# Altium schematic sheet MCU.SchDoc(MCU)
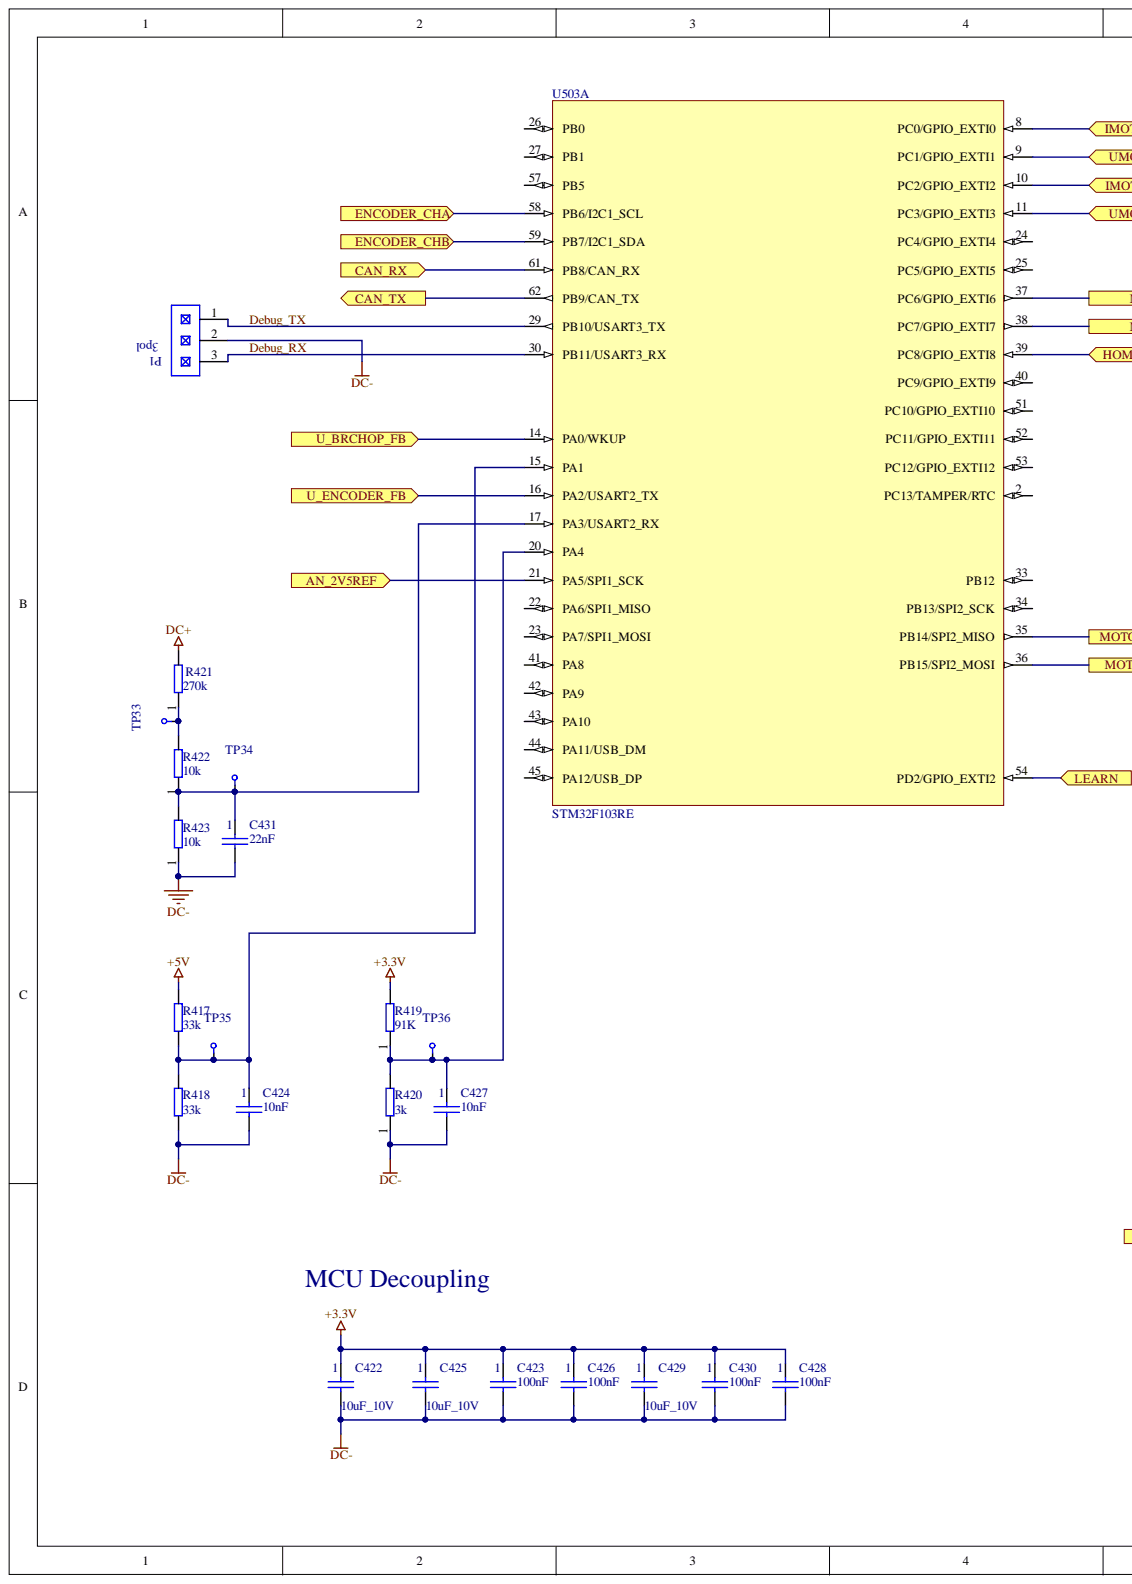
[diagram p0: MCU.SchDoc, left]
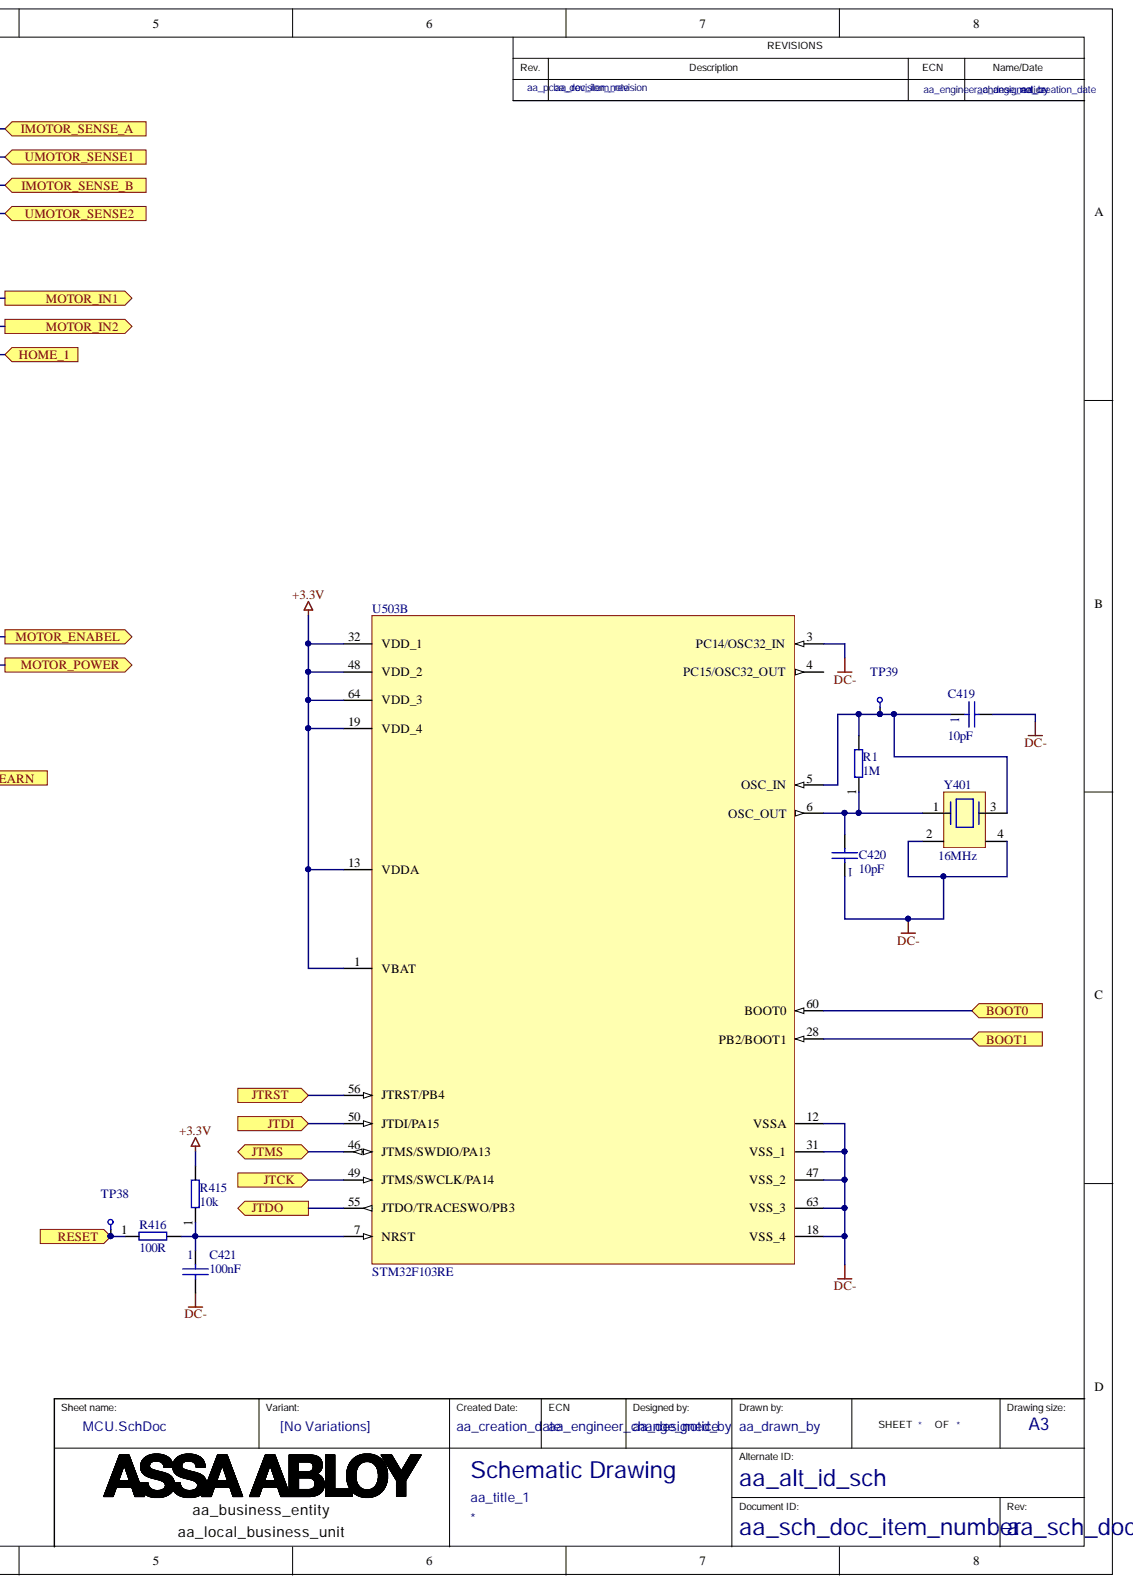
[diagram p1: MCU.SchDoc, right]

## Parts
C419  10pF  [CAPC1608X08N]
C420  10pF  [CAPC1608X08N]
C421  100nF  [CAPC1608X08N]
C422  10uF_10V  [0603C/H]
C423  100nF  [CAPC1608X08N]
C424  10nF  [CAPC1608X08N]
C425  10uF_10V  [0603C/H]
C426  100nF  [CAPC1608X08N]
C427  10nF  [CAPC1608X08N]
C428  100nF  [CAPC1608X08N]
C429  10uF_10V  [0603C/H]
C430  100nF  [CAPC1608X08N]
C431  22nF  [CAPC1608X08N]
P1  3pol  [HDRV3W64P254_3X1_762X248H838]
R1  1M  [RESC1608X55N]
R415  10k  [RESC1608X05N]
R416  100R  [RESC1608X05N]
R417  33k  [RESC1608X05N]
R418  33k  [RESC1608X05N]
R419  91K  [RESC1608X55N]
R420  3k  [RESC1608X05N]
R421  270k  [RESC1608X05N]
R422  10k  [RESC1608X05N]
R423  10k  [RESC1608X05N]
TP33  Testpoint SMD  [PCB_PARTS_TESTPOINT100_SMD]  (value not drawn)  (no BOM)
TP34  Testpoint SMD  [PCB_PARTS_TESTPOINT100_SMD]  (value not drawn)  (no BOM)
TP35  Testpoint SMD  [PCB_PARTS_TESTPOINT100_SMD]  (value not drawn)  (no BOM)
TP36  Testpoint SMD  [PCB_PARTS_TESTPOINT100_SMD]  (value not drawn)  (no BOM)
TP38  Testpoint SMD  [PCB_PARTS_TESTPOINT100_SMD]  (value not drawn)  (no BOM)
TP39  Testpoint SMD  [PCB_PARTS_TESTPOINT100_SMD]  (value not drawn)  (no BOM)
U503  STM32F103RE  [TSQFP50P1200X1200X160-64N]  (parts A,B)
Y401  16MHz  [XTAL_TSX-3225]

## Ports
AN_2V5REF, BOOT0, BOOT1, CAN_RX, CAN_TX, ENCODER_CHA, ENCODER_CHB, HOME_1, IMOTOR_SENSE_A, IMOTOR_SENSE_B, JTCK, JTDI, JTDO, JTMS, JTRST, LEARN, MOTOR_ENABEL, MOTOR_IN1, MOTOR_IN2, MOTOR_POWER, RESET, U_BRCHOP_FB, U_ENCODER_FB, UMOTOR_SENSE1, UMOTOR_SENSE2

## Nets
+3.3V: C422.1 C423.1 C425.1 C426.1 C428.1 C429.1 C430.1 R415.2 R419.2 U503.1(VBAT) U503.13(VDDA) U503.19(VDD_4) U503.32(VDD_1) U503.48(VDD_2) U503.64(VDD_3)
+5V: R417.2
DC+: R421.2
DC-: C419.2 C420.1 C421.2 C422.2 C423.2 C424.2 C425.2 C426.2 C427.2 C428.2 C429.2 C430.2 C431.2 P1.2 R418.1 R420.1 R423.1 U503.3(PC14/OSC32_IN) U503.12(VSSA) U503.18(VSS_4) U503.31(VSS_1) U503.47(VSS_2) U503.63(VSS_3) Y401.2 Y401.4
AN_2V5REF: U503.21(PA5/SPI1_SCK) ; port AN_2V5REF
BOOT0: U503.60(BOOT0) ; port BOOT0
BOOT1: U503.28(PB2/BOOT1) ; port BOOT1
CAN_RX: U503.61(PB8/CAN_RX) ; port CAN_RX
CAN_TX: U503.62(PB9/CAN_TX) ; port CAN_TX
Debug_RX: P1.3 U503.30(PB11/USART3_RX)
Debug_TX: P1.1 U503.29(PB10/USART3_TX)
ENCODER_CHA: U503.58(PB6/I2C1_SCL) ; port ENCODER_CHA
ENCODER_CHB: U503.59(PB7/I2C1_SDA) ; port ENCODER_CHB
HOME_1: U503.39(PC8/GPIO_EXTI8) ; port HOME_1
IMOTOR_SENSE_A: U503.8(PC0/GPIO_EXTI0) ; port IMOTOR_SENSE_A
IMOTOR_SENSE_B: U503.10(PC2/GPIO_EXTI2) ; port IMOTOR_SENSE_B
JTCK: U503.49(JTMS/SWCLK/PA14) ; port JTCK
JTDI: U503.50(JTDI/PA15) ; port JTDI
JTDO: U503.55(JTDO/TRACESWO/PB3) ; port JTDO
JTMS: U503.46(JTMS/SWDIO/PA13) ; port JTMS
JTRST: U503.56(JTRST/PB4) ; port JTRST
LEARN: U503.54(PD2/GPIO_EXTI2) ; port LEARN
MOTOR_ENABEL: U503.35(PB14/SPI2_MISO) ; port MOTOR_ENABEL
MOTOR_IN1: U503.37(PC6/GPIO_EXTI6) ; port MOTOR_IN1
MOTOR_IN2: U503.38(PC7/GPIO_EXTI7) ; port MOTOR_IN2
MOTOR_POWER: U503.36(PB15/SPI2_MOSI) ; port MOTOR_POWER
RESET: R416.1 TP38.1 ; port RESET
U_BRCHOP_FB: U503.14(PA0/WKUP) ; port U_BRCHOP_FB
U_ENCODER_FB: U503.16(PA2/USART2_TX) ; port U_ENCODER_FB
UMOTOR_SENSE1: U503.9(PC1/GPIO_EXTI1) ; port UMOTOR_SENSE1
UMOTOR_SENSE2: U503.11(PC3/GPIO_EXTI3) ; port UMOTOR_SENSE2
NetC419_1: C419.1 R1.2 TP39.1 U503.5(OSC_IN) Y401.3
NetC420_2: C420.2 R1.1 U503.6(OSC_OUT) Y401.1
NetC421_1: C421.1 R415.1 R416.2 U503.7(NRST)
NetC424_1: C424.1 R417.1 R418.2 TP35.1 U503.15(PA1)
NetC427_1: C427.1 R419.1 R420.2 TP36.1 U503.20(PA4)
NetC431_1: C431.1 R422.1 R423.2 TP34.1 U503.17(PA3/USART2_RX)
NetR421_1: R421.1 R422.2 TP33.1

Not connected: U503 2(PC13/TAMPER/RTC) 4(PC15/OSC32_OUT) 22(PA6/SPI1_MISO) 23(PA7/SPI1_MOSI) 24(PC4/GPIO_EXTI4) 25(PC5/GPIO_EXTI5) 26(PB0) 27(PB1) 33(PB12) 34(PB13/SPI2_SCK) 40(PC9/GPIO_EXTI9) 41(PA8) 42(PA9) 43(PA10) 44(PA11/USB_DM) 45(PA12/USB_DP) 51(PC10/GPIO_EXTI10) 52(PC11/GPIO_EXTI11) 53(PC12/GPIO_EXTI12) 57(PB5)

## Library data of the parts
C419 C420 = 1700530: Capacitor; APPROVED_MPN_01: C1608CH1H100D  RoHS; APPROVED_MANUFACTURER_01: TDK; PHYSICALPACKAGE: EIA0603; MOUNTEDTYPE: SMD; Database Table Name: Capacitors_Ceramic; VALUE: 10pF; TOLERANCE: -; PROTOTYPE_MPN_01: -; PROTOTYPE_MANUFACTURER_01: -; POWERRATING: -; PARTNUMBER: 1700530; PARTNAME: Capacitor; Library Name: AltiumLib_Database.DbLib; Component Kind: Standard; APPROVED_MPN_08: -; APPROVED_MPN_07: -; APPROVED_MPN_06: -; APPROVED_MPN_05: -; APPROVED_MPN_04: -; APPROVED_MPN_03: -; APPROVED_MPN_02: -; APPROVED_MANUFACTURER_08: -; APPROVED_MANUFACTURER_07: -; APPROVED_MANUFACTURER_06: -; APPROVED_MANUFACTURER_05: -; APPROVED_MANUFACTURER_04: -; APPROVED_MANUFACTURER_03: -; APPROVED_MANUFACTURER_02: -
C421 C423 C426 C428 C430 = 715219: Capacitor; APPROVED_MPN_05: 223878615649 ,X7R, 0603, 10%; APPROVED_MPN_04: CC0603KRX7R7BB104; APPROVED_MPN_03: 0603YC104KAT ,X7R,0603,10%; APPROVED_MPN_02: GRM188R71C104KA01; APPROVED_MPN_01: CL10B104KO8NNN; APPROVED_MANUFACTURER_05: Yageo Phy; APPROVED_MANUFACTURER_04: YAGEO; APPROVED_MANUFACTURER_03: AVX KYOCERA; APPROVED_MANUFACTURER_02: MURATA; APPROVED_MANUFACTURER_01: SAMSUNG; PHYSICALPACKAGE: EIA0603; MOUNTEDTYPE: SMD; Database Table Name: Capacitors_Ceramic; VALUE: 100nF; TOLERANCE: -; PROTOTYPE_MPN_01: -; PROTOTYPE_MANUFACTURER_01: -; POWERRATING: -; PARTNUMBER: 715219; PARTNAME: Capacitor; Library Name: AltiumLib_Database.DbLib; Component Kind: Standard; APPROVED_MPN_08: -; APPROVED_MPN_07: -; APPROVED_MPN_06: -; APPROVED_MANUFACTURER_08: -; APPROVED_MANUFACTURER_07: -; APPROVED_MANUFACTURER_06: -
C422 C425 C429 = Capacitor-NonPolarized: 0603; Library Name: Capacitor-NonPolarized.SchLib; Component Kind: Standard
C424 C427 = 1700542: Capacitor; APPROVED_MPN_01: C1608CH1E103J  RoHS; APPROVED_MANUFACTURER_01: TDK; PHYSICALPACKAGE: EIA0603; MOUNTEDTYPE: SMD; Database Table Name: Capacitors_Ceramic; VALUE: 10nF; TOLERANCE: -; PROTOTYPE_MPN_01: -; PROTOTYPE_MANUFACTURER_01: -; POWERRATING: -; PARTNUMBER: 1700542; PARTNAME: Capacitor; Library Name: AltiumLib_Database.DbLib; Component Kind: Standard; APPROVED_MPN_08: -; APPROVED_MPN_07: -; APPROVED_MPN_06: -; APPROVED_MPN_05: -; APPROVED_MPN_04: -; APPROVED_MPN_03: -; APPROVED_MPN_02: -; APPROVED_MANUFACTURER_08: -; APPROVED_MANUFACTURER_07: -; APPROVED_MANUFACTURER_06: -; APPROVED_MANUFACTURER_05: -; APPROVED_MANUFACTURER_04: -; APPROVED_MANUFACTURER_03: -; APPROVED_MANUFACTURER_02: -
C431 = 715500: Capacitor; APPROVED_MPN_04: 2238586_5641 (RoHS); APPROVED_MPN_03: GRM188R71H223KA01_ (RoHS); APPROVED_MPN_02: C0603C223K5RAC (RoHS); APPROVED_MPN_01: 06035C223KAT2A (RoHS); APPROVED_MANUFACTURER_04: Yageo Phycomp.; APPROVED_MANUFACTURER_03: MURATA; APPROVED_MANUFACTURER_02: KEMET; APPROVED_MANUFACTURER_01: AVX; PHYSICALPACKAGE: EIA0603; MOUNTEDTYPE: SMD; Database Table Name: Capacitors_Ceramic; VALUE: 22nF; TOLERANCE: -; PROTOTYPE_MPN_01: -; PROTOTYPE_MANUFACTURER_01: -; POWERRATING: -; PARTNUMBER: 715500; PARTNAME: Capacitor; Library Name: AltiumLib_Database.DbLib; Component Kind: Standard; APPROVED_MPN_08: -; APPROVED_MPN_07: -; APPROVED_MPN_06: -; APPROVED_MPN_05: -; APPROVED_MANUFACTURER_08: -; APPROVED_MANUFACTURER_07: -; APPROVED_MANUFACTURER_06: -; APPROVED_MANUFACTURER_05: -
P1 = 707279: 3pol connector; APPROVED_MPN_06: 1125-1103GOS11201; APPROVED_MPN_05: 4711955103490; APPROVED_MPN_04: 0-826646-3; APPROVED_MPN_03: 2211S-03G; APPROVED_MPN_02: 010 01 80 112 003; APPROVED_MPN_01: STL1-1080-GGT-003-U; APPROVED_MANUFACTURER_06: WCON; APPROVED_MANUFACTURER_05: Kontek-Comatel; APPROVED_MANUFACTURER_04: AMP; APPROVED_MANUFACTURER_03: Neltron; APPROVED_MANUFACTURER_02: Ratioplast; APPROVED_MANUFACTURER_01: MPE-GARRY; PHYSICALPACKAGE: 3pol 2.54mm; MOUNTEDTYPE: SMD; Database Table Name: CONNECTORS; VALUE: 3pol; TOLERANCE: -; PROTOTYPE_MPN_01: -; PROTOTYPE_MANUFACTURER_01: -; POWERRATING: -; PARTNUMBER: 707279; PARTNAME: 3pol; Library Name: AltiumLib_Database.DbLib; Component Kind: Standard; APPROVED_MPN_08: -; APPROVED_MPN_07: -; APPROVED_MANUFACTURER_08: -; APPROVED_MANUFACTURER_07: -
R1 = 714858: Resistor; APPROVED_MPN_05: MCT0603-50,1%,1M Lead Free (RoHS); APPROVED_MPN_04: MCR03-X-F-1M (RoHS); APPROVED_MPN_03: RK73H1JTTD1MF (RoHS); APPROVED_MPN_02: RC22H,2322704X19101; APPROVED_MPN_01: D11, 1M/1% ET_ E3 (RoHS); APPROVED_MANUFACTURER_05: BEYSCHLAG; APPROVED_MANUFACTURER_04: ROHM; APPROVED_MANUFACTURER_03: KOA; APPROVED_MANUFACTURER_02: Yageo; APPROVED_MANUFACTURER_01: Vishay OKAB ROE; TOLERANCE: 1%; POWERRATING: 0.063W; PHYSICALPACKAGE: EIA0603; MOUNTEDTYPE: SMD; Database Table Name: Resistors; VALUE: 1M; PROTOTYPE_MPN_01: -; PROTOTYPE_MANUFACTURER_01: -; PARTNUMBER: 714858; PARTNAME: 1M; Library Name: AltiumLib_Database.DbLib; Component Kind: Standard; APPROVED_MPN_08: -; APPROVED_MPN_07: -; APPROVED_MPN_06: -; APPROVED_MANUFACTURER_08: -; APPROVED_MANUFACTURER_07: -; APPROVED_MANUFACTURER_06: -
R415 R422 R423 = 714811: Resistor; APPROVED_MPN_05: MCR03-X-F-10k (RoHS); APPROVED_MPN_04: RK73H1JTTD10kF (RoHS); APPROVED_MPN_03: RC22 ,2322704X11003L (RoHS); APPROVED_MPN_02: MCT0603-50,1%,10k ET_ E3 (RoHS); APPROVED_MPN_01: D11, 10k/1% ET_ E3 (RoHS); APPROVED_MANUFACTURER_05: ROHM; APPROVED_MANUFACTURER_04: KOA; APPROVED_MANUFACTURER_03: Yageo; APPROVED_MANUFACTURER_02: Vishay; TOLERANCE: 1%; POWERRATING: 0.063W; PHYSICALPACKAGE: EIA0603; MOUNTEDTYPE: SMD; Database Table Name: Resistors; VALUE: 10k; PROTOTYPE_MPN_01: -; PROTOTYPE_MANUFACTURER_01: -; PARTNUMBER: 714811; PARTNAME: 10k; Library Name: AltiumLib_Database.DbLib; Component Kind: Standard; APPROVED_MPN_08: -; APPROVED_MPN_07: -; APPROVED_MPN_06: -; APPROVED_MANUFACTURER_08: -; APPROVED_MANUFACTURER_07: -; APPROVED_MANUFACTURER_06: -; APPROVED_MANUFACTURER_01: Vishay
R416 = 714764: Resistor; APPROVED_MPN_05: MCT0603-50,1%,100R; APPROVED_MPN_04: MCR03-X-F-100R; APPROVED_MPN_03: RK73H1JTD100RF; APPROVED_MPN_02: RC22H,2322704X11001; APPROVED_MPN_01: D11, 100R/1%; APPROVED_MANUFACTURER_05: BEYSCHLAG; APPROVED_MANUFACTURER_04: ROHM; APPROVED_MANUFACTURER_03: KOA; APPROVED_MANUFACTURER_02: PHILIPS; APPROVED_MANUFACTURER_01: OKAB ROE; TOLERANCE: 1%; POWERRATING: 0.063W; PHYSICALPACKAGE: EIA0603; MOUNTEDTYPE: SMD; Database Table Name: Resistors; VALUE: 100R; PROTOTYPE_MPN_01: -; PROTOTYPE_MANUFACTURER_01: -; PARTNUMBER: 714764; PARTNAME: 100R; Library Name: AltiumLib_Database.DbLib; Component Kind: Standard; APPROVED_MPN_08: -; APPROVED_MPN_07: -; APPROVED_MPN_06: -; APPROVED_MANUFACTURER_08: -; APPROVED_MANUFACTURER_07: -; APPROVED_MANUFACTURER_06: -
R417 R418 = 714823: Resistor; APPROVED_MPN_05: MCT0603-50,1%,33k Lead Free (RoHS); APPROVED_MPN_04: MCR03-X-F-33k (RoHS); APPROVED_MPN_03: RK73H1JTTD33kF (RoHS); APPROVED_MPN_02: RC22H,2322704X3303L (RoHS); APPROVED_MPN_01: D11, 33k/1% ET_ E3 (RoHS); APPROVED_MANUFACTURER_05: BEYSCHLAG; APPROVED_MANUFACTURER_04: ROHM; APPROVED_MANUFACTURER_03: KOA; APPROVED_MANUFACTURER_02: Yageo; APPROVED_MANUFACTURER_01: OKAB ROE; TOLERANCE: 1%; POWERRATING: 0.063W; PHYSICALPACKAGE: EIA0603; MOUNTEDTYPE: SMD; Database Table Name: Resistors; VALUE: 33k; PROTOTYPE_MPN_01: -; PROTOTYPE_MANUFACTURER_01: -; PARTNUMBER: 714823; PARTNAME: 33k; Library Name: AltiumLib_Database.DbLib; Component Kind: Standard; APPROVED_MPN_08: -; APPROVED_MPN_07: -; APPROVED_MPN_06: -; APPROVED_MANUFACTURER_08: -; APPROVED_MANUFACTURER_07: -; APPROVED_MANUFACTURER_06: -
R419 = 714834: Resistor; APPROVED_MPN_05: MCT0603-50,1%,91k (RoHS); APPROVED_MPN_04: MCR03-X-F-91k (RoHS); APPROVED_MPN_03: RK73H1JTTD91kF (RoHS); APPROVED_MPN_02: RC22H,2322704X9103L (RoHS); APPROVED_MPN_01: D11, 91k/1% ET_ E3 (RoHS); APPROVED_MANUFACTURER_05: BEYSCHLAG; APPROVED_MANUFACTURER_04: ROHM; APPROVED_MANUFACTURER_03: KOA; APPROVED_MANUFACTURER_02: Yageo; APPROVED_MANUFACTURER_01: Vishay OKAB ROE; TOLERANCE: 1%; POWERRATING: 0.063W; PHYSICALPACKAGE: EIA0603; MOUNTEDTYPE: SMD; Database Table Name: Resistors; VALUE: 91K; PROTOTYPE_MPN_01: -; PROTOTYPE_MANUFACTURER_01: -; PARTNUMBER: 714834; PARTNAME: 91K; Library Name: AltiumLib_Database.DbLib; Component Kind: Standard; APPROVED_MPN_08: -; APPROVED_MPN_07: -; APPROVED_MPN_06: -; APPROVED_MANUFACTURER_08: -; APPROVED_MANUFACTURER_07: -; APPROVED_MANUFACTURER_06: -
R420 = 714798: Resistor; APPROVED_MPN_05: MCT0603-50,1%,3k (RoHS); APPROVED_MPN_04: MCR03-X-F-3k (RoHS); APPROVED_MPN_03: RK73H1JTTD3kF (RoHS); APPROVED_MPN_02: RC22H,2322704X3002L (RoHS); APPROVED_MPN_01: D11, 3k/1% ET_ E3 (RoHS); APPROVED_MANUFACTURER_05: BEYSCHLAG; APPROVED_MANUFACTURER_04: ROHM; APPROVED_MANUFACTURER_03: KOA; APPROVED_MANUFACTURER_02: Yageo; APPROVED_MANUFACTURER_01: OKAB ROE; TOLERANCE: 1%; POWERRATING: 0.063W; PHYSICALPACKAGE: EIA0603; MOUNTEDTYPE: SMD; Database Table Name: Resistors; VALUE: 3k; PROTOTYPE_MPN_01: -; PROTOTYPE_MANUFACTURER_01: -; PARTNUMBER: 714798; PARTNAME: 3k; Library Name: AltiumLib_Database.DbLib; Component Kind: Standard; APPROVED_MPN_08: -; APPROVED_MPN_07: -; APPROVED_MPN_06: -; APPROVED_MANUFACTURER_08: -; APPROVED_MANUFACTURER_07: -; APPROVED_MANUFACTURER_06: -
R421 = 714844: Resistor; APPROVED_MPN_05: MCT0603-50,1%,270k; APPROVED_MPN_04: MCR03-X-F-270k; APPROVED_MPN_03: RK73H1JTD270kF; APPROVED_MPN_02: RC22H,2322704X12704; APPROVED_MPN_01: D11, 270k/1%; APPROVED_MANUFACTURER_05: BEYSCHLAG; APPROVED_MANUFACTURER_04: ROHM; APPROVED_MANUFACTURER_03: KOA; APPROVED_MANUFACTURER_02: PHILIPS; APPROVED_MANUFACTURER_01: OKAB ROE; TOLERANCE: 1%; POWERRATING: 0.063W; PHYSICALPACKAGE: EIA0603; MOUNTEDTYPE: SMD; Database Table Name: Resistors; VALUE: 270k; PROTOTYPE_MPN_01: -; PROTOTYPE_MANUFACTURER_01: -; PARTNUMBER: 714844; PARTNAME: 270k; Library Name: AltiumLib_Database.DbLib; Component Kind: Standard; APPROVED_MPN_08: -; APPROVED_MPN_07: -; APPROVED_MPN_06: -; APPROVED_MANUFACTURER_08: -; APPROVED_MANUFACTURER_07: -; APPROVED_MANUFACTURER_06: -
TP33-TP36 TP38 TP39 = 1006: Testpoint; PHYSICALPACKAGE: 1mm SMD; Database Table Name: PCB_Parts; VALUE: Testpoint SMD; TOLERANCE: -; PROTOTYPE_MPN_01: -; PROTOTYPE_MANUFACTURER_01: -; POWERRATING: -; PARTNUMBER: 1006; PARTNAME: -; MOUNTEDTYPE: -; Library Name: AltiumLib_Database.DbLib; APPROVED_MPN_08: -; APPROVED_MPN_07: -; APPROVED_MPN_06: -; APPROVED_MPN_05: -; APPROVED_MPN_04: -; APPROVED_MPN_03: -; APPROVED_MPN_02: -; APPROVED_MPN_01: -; APPROVED_MANUFACTURER_08: -; APPROVED_MANUFACTURER_07: -; APPROVED_MANUFACTURER_06: -; APPROVED_MANUFACTURER_05: -; APPROVED_MANUFACTURER_04: -; APPROVED_MANUFACTURER_03: -; APPROVED_MANUFACTURER_02: -; APPROVED_MANUFACTURER_01: -
U503 = 1703392: ARM32-bit Cortex M3 CPU; PARTNAME: MCU; APPROVED_MPN_01: STM32F103RET6; APPROVED_MANUFACTURER_01: ST; PHYSICALPACKAGE: LQFP64; MOUNTEDTYPE: SMD; Database Table Name: IC_MCU; VALUE: STM32F103RE; TOLERANCE: -; PROTOTYPE_MPN_01: -; PROTOTYPE_MANUFACTURER_01: -; POWERRATING: -; PARTNUMBER: 1703392; Library Name: AltiumLib_Database.DbLib; Component Kind: Standard; APPROVED_MPN_08: -; APPROVED_MPN_07: -; APPROVED_MPN_06: -; APPROVED_MPN_05: -; APPROVED_MPN_04: -; APPROVED_MPN_03: -; APPROVED_MPN_02: -; APPROVED_MANUFACTURER_08: -; APPROVED_MANUFACTURER_07: -; APPROVED_MANUFACTURER_06: -; APPROVED_MANUFACTURER_05: -; APPROVED_MANUFACTURER_04: -; APPROVED_MANUFACTURER_03: -; APPROVED_MANUFACTURER_02: -
Y401 = 1703715: APPROVED_MPN_01: TSX-3225 16MHZ 10pF +10%(X1E0000210113__); APPROVED_MANUFACTURER_01: EPSON; PHYSICALPACKAGE: 3.2x2.5x0.6; MOUNTEDTYPE: SMD; Database Table Name: Crystals_Oscillators_Resonator; VALUE: 16MHz; TOLERANCE: -; PROTOTYPE_MPN_01: -; PROTOTYPE_MANUFACTURER_01: -; POWERRATING: -; PARTNUMBER: 1703715; PARTNAME: TSX-3225; Library Name: AltiumLib_Database.DbLib; Component Kind: Standard; APPROVED_MPN_08: -; APPROVED_MPN_07: -; APPROVED_MPN_06: -; APPROVED_MPN_05: -; APPROVED_MPN_04: -; APPROVED_MPN_03: -; APPROVED_MPN_02: -; APPROVED_MANUFACTURER_08: -; APPROVED_MANUFACTURER_07: -; APPROVED_MANUFACTURER_06: -; APPROVED_MANUFACTURER_05: -; APPROVED_MANUFACTURER_04: -; APPROVED_MANUFACTURER_03: -; APPROVED_MANUFACTURER_02: -
